# Altium schematic sheet Super Cap_PowerSupply.SchDoc
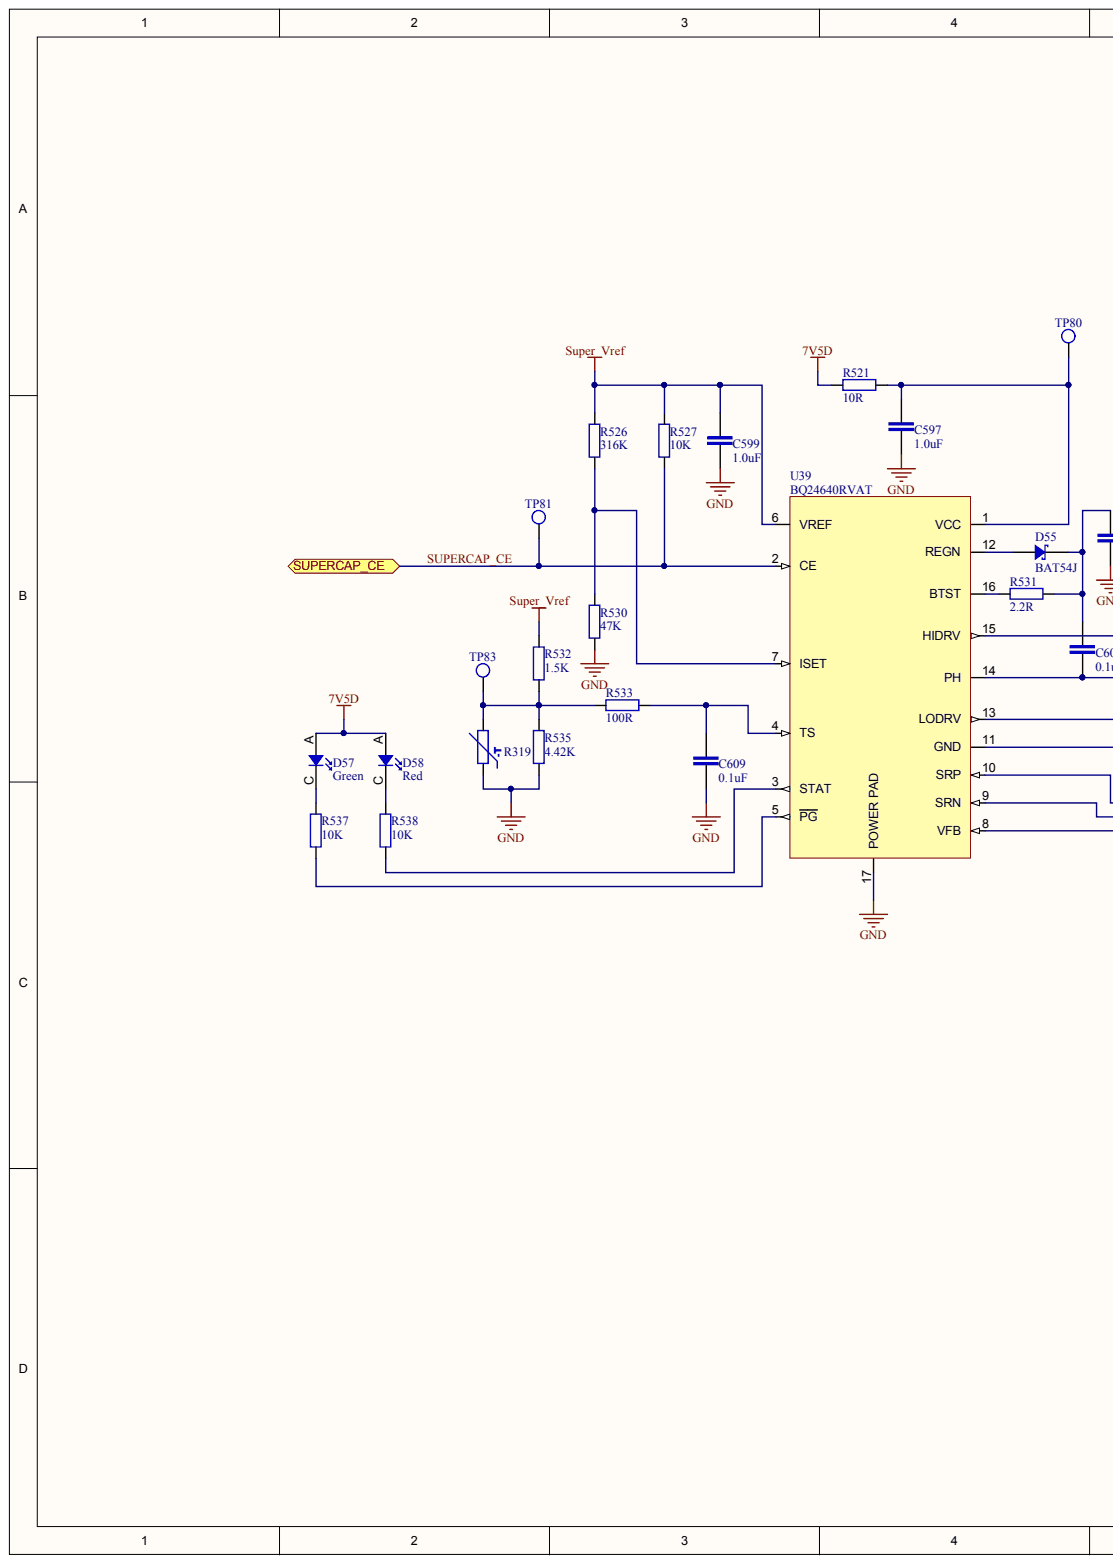
[diagram p0: Super Cap_PowerSupply.SchDoc, left]
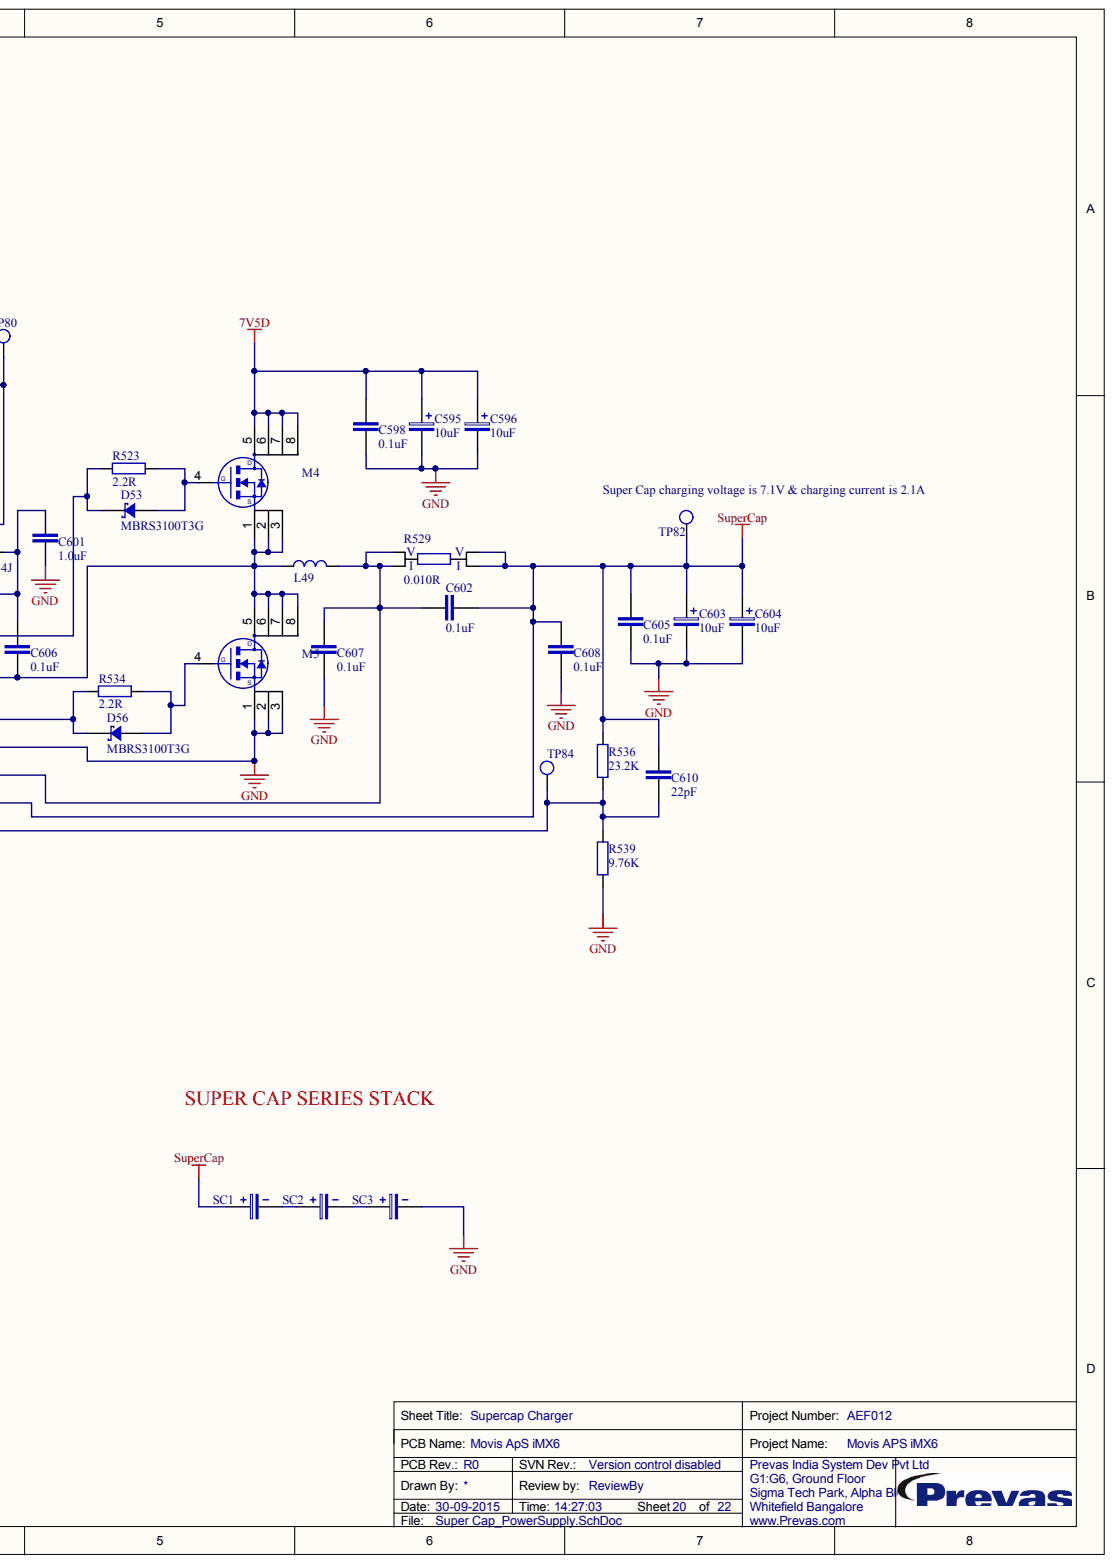
[diagram p1: Super Cap_PowerSupply.SchDoc, right]

## Parts
C595  10uF  [CAPMP7343X200N]
C596  10uF  [CAPMP7343X200N]
C597  1.0uF  [CAPC1608X90N]
C598  0.1uF  [CAPC1005X55N]
C599  1.0uF  [CAPC1608X90N]
C601  1.0uF  [CAPC1608X90N]
C602  0.1uF  [CAPC1005X55N]
C603  10uF  [CAPMP7343X200N]
C604  10uF  [CAPMP7343X200N]
C605  0.1uF  [CAPC1005X55N]
C606  0.1uF  [CAPC1005X55N]
C607  0.1uF  [CAPC1005X55N]
C608  0.1uF  [CAPC1005X55N]
C609  0.1uF  [CAPC1005X55N]
C610  22pF  [CAPC1005X55N]
D53  MBRS3100T3G  [DIOM7959X241AN]
D55  BAT54J  [SOD323F]
D56  MBRS3100T3G  [DIOM7959X241AN]
D57  Green  [LED_19-219_G7C-BK1L2VY_3T]
D58  Red  [LED_19-219_G7C-BK1L2VY_3T]
L49  6.8 uH  [INDM103103X40N]  (value not drawn)
M4  CSD19533Q5A  [SON127P600X110_HS-8N]  (value not drawn)
M5  CSD19533Q5A  [SON127P600X110_HS-8N]  (value not drawn)
R319  RES_NTC  [RESC1608X95N]  (value not drawn)
R521  10R  [RESC1608X55N]
R523  2.2R  [RESC2012X60N]
R526  316K  [RESC1608X55N]
R527  10K  [RESC1608X55N]
R529  0.010R  [RES_LVK12R010DER]
R530  47K  [RESC1608X55N]
R531  2.2R  [RESC2012X60N]
R532  1.5K  [RESC1608X55N]
R533  100R  [RESC1608X55N]
R534  2.2R  [RESC2012X60N]
R535  4.42K  [RESC1608X55N]
R536  23.2K  [RESC1608X55N]
R537  10K  [RESC1608X55N]
R538  10K  [RESC1608X55N]
R539  9.76K  [RESC1608X55N]
SC1  50F  [BCAP0050 P270 T01]  (value not drawn)
SC2  50F  [BCAP0050 P270 T01]  (value not drawn)
SC3  50F  [BCAP0050 P270 T01]  (value not drawn)
TP80  Testpoint  [TP_SMD]  (value not drawn)  (no BOM)
TP81  Testpoint  [TP_SMD]  (value not drawn)  (no BOM)
TP82  Testpoint  [TP_SMD]  (value not drawn)  (no BOM)
TP83  Testpoint  [TP_SMD]  (value not drawn)  (no BOM)
TP84  Testpoint  [TP_SMD]  (value not drawn)  (no BOM)
U39  BQ24640RVAT  [QFN50P350X350X100_HS-17N]

## Ports
SUPERCAP_CE

## Nets
7V5D: C595.1 C596.1 C598.2 D57.A D58.A M4.5 M4.6 M4.7 M4.8 R521.1
GND: C595.2 C596.2 C597.1 C598.1 C599.1 C601.1 C603.2 C604.2 C605.1 C607.2 C608.2 C609.1 M5.1 M5.2 M5.3 R319.1 R530.1 R535.1 R539.1 SC3.2 U39.11(GND) U39.17(POWER_PAD)
SUPER_VREF: C599.2 R526.2 R527.2 R532.2 U39.6(VREF)
SUPERCAP: C602.1 C603.1 C604.1 C605.2 C608.1 C610.2 R529.3(I) R529.4(V) R536.2 SC1.1 TP82.1 U39.9(SRN)
SUPERCAP_CE: R527.1 TP81.1 U39.2(CE) ; port SUPERCAP_CE
NetC597_2: C597.2 R521.2 TP80.1 U39.1(VCC)
NetC601_2: C601.2 C606.2 D55.C R531.2
NetC602_2: C602.2 C607.1 L49.2 R529.1(V) R529.2(I) U39.10(SRP)
NetC606_1: C606.1 L49.1 M4.1 M4.2 M4.3 M5.5 M5.6 M5.7 M5.8 U39.14(PH)
NetC609_2: C609.2 R533.2 U39.4(TS)
NetC610_1: C610.1 R536.1 R539.2 TP84.1 U39.8(VFB)
NetD53_A: D53.A M4.4(G) R523.2
NetD53_C: D53.C R523.1 U39.15(HIDRV)
NetD55_A: D55.A U39.12(REGN)
NetD56_A: D56.A M5.4 R534.2
NetD56_C: D56.C R534.1 U39.13(LODRV)
NetD57_C: D57.C R537.2
NetD58_C: D58.C R538.2
NetR319_2: R319.2 R532.1 R533.1 R535.2 TP83.1
NetR526_1: R526.1 R530.2 U39.7(ISET)
NetR531_1: R531.1 U39.16(BTST)
NetR537_1: R537.1 U39.5(PG)
NetR538_1: R538.1 U39.3(STAT)
NetSC1_2: SC1.2 SC2.1
NetSC2_2: SC2.2 SC3.1

## Library data of the parts
C595 C596 C603 C604 = IBAT-24: Solid Tantalum Standard; ref_part_type: /capacitor/polarized/polymer/; source_manufacturer_1: AVX; source_manufacturer_1_pn: TPSD106K035R0125; cae_altium_pcb_status: Prototype; Database Table Name: altium_default; db_rev: 1331; elec_temp_range: -55 to 125; elec_tolerance: 10%; elec_voltage_rating: 35V; phy_height: 7.3mm; phy_mounting: SMD; phy_packaging_type: D-case; ref_datasheet: IBAT-20; cae_altium_sch_status: Prototype; Component Kind: Standard; ComponentLink1Description: Datasheet; ComponentLink1URL: https://cop201.prevas.dk/viewvc/datasheet/IBAT-20.pdf?root=hw_components&view=co; db_created: 2008-11-07 13:55:01; db_last_updated: 20-05-2009 15:28:47; db_local_id: IBAT-24; db_status: Prototype; elec_value: 10uF; phy_material: Tantalum; Library Name: altium_mysql.dblib
C597 C599 C601 = INNISI-79: CAP CER 1UF 16V 10% X7R 0603; ref_part_type: /capacitor/non_polarized/ceramic/; source_manufacturer_1: Murata; source_manufacturer_1_pn: GRM188R71C105KE15D; cae_altium_pcb_status: Prototype; Database Table Name: altium_default; db_rev: 7576; elec_temp_range: -55 to +125; phy_height: 0.90mm; phy_mounting: SMD; phy_packaging_type: 0603 (1608 Metric); ref_datasheet: INNISI-33; ref_keyword: Ceramic Capacitor 0603; cae_altium_sch_status: Prototype; Component Kind: Standard; ComponentLink1Description: Datasheet; ComponentLink1URL: https://cop201.prevas.dk/viewvc/datasheet/INNISI-33.pdf?root=hw_components&view=co; db_created: 2015-08-03 05:39:06.0; db_last_updated: 03-08-2015 05:39:08; db_local_id: INNISI-79; db_status: Prototype; elec_tolerance: 10%; elec_value: 1.0uF; elec_voltage_rating: 16V; phy_material: X7R; Library Name: altium_mysql.dblib
C598 C602 C605-C609 = INNISI-73: CAP CER 0.1UF 50V 10% X5R 0402; ref_part_type: /capacitor/non_polarized/ceramic/; source_manufacturer_1: TDK Corporation; source_manufacturer_1_pn: C1005X5R1H104K050BB; cae_altium_pcb_status: Prototype; Database Table Name: altium_default; db_rev: 7569; elec_temp_range: -55 to +85 C; phy_height: 0.55mm; phy_mounting: SMD; phy_packaging_type: 0402; ref_datasheet: INNISI-71; cae_altium_sch_status: Prototype; Component Kind: Standard; ComponentLink1Description: Datasheet; ComponentLink1URL: https://cop201.prevas.dk/viewvc/datasheet/INNISI-71.pdf?root=hw_components&view=co; db_created: 2015-08-03 03:34:59.0; db_last_updated: 03-08-2015 03:35:01; db_local_id: INNISI-73; db_status: Prototype; elec_tolerance: ±10%; elec_value: 0.1uF; elec_voltage_rating: 50V; phy_material: X5R; ref_keyword: CAP CER 0.1UF 50V 10% X5R 0402; Library Name: altium_mysql.dblib
C610 = INNISI-83: CAP CER 22PF 50V 5% NP0 0402; ref_part_type: /capacitor/non_polarized/ceramic/; source_manufacturer_1: Murata; source_manufacturer_1_pn: GCM1555C1H220JA16D; cae_altium_pcb_status: Prototype; Database Table Name: altium_default; db_rev: 7582; elec_temp_range: -55 to +125C; phy_height: 0.55mm; phy_material: C0G; phy_mounting: SMD; phy_packaging_type: 0402; ref_datasheet: INNISI-76; ref_keyword: Ceramic capacitor; cae_altium_sch_status: Prototype; Component Kind: Standard; ComponentLink1Description: Datasheet; ComponentLink1URL: https://cop201.prevas.dk/viewvc/datasheet/INNISI-76.pdf?root=hw_components&view=co; db_created: 2015-08-03 06:17:55.0; db_last_updated: 03-08-2015 06:17:58; db_local_id: INNISI-83; db_status: Prototype; elec_tolerance: 5%; elec_value: 22pF; elec_voltage_rating: 50V; Library Name: altium_mysql.dblib
D53 D56 = MITO-15: Diode Power Rectifier Case 403; ref_part_type: /diode/schottky/; source_manufacturer_1: ON Semiconductor; cae_altium_pcb_status: Prototype; Database Table Name: altium_default; db_rev: 2168; elec_current_rating: 3A; elec_temp_range: -65 to +175°C; elec_voltage_rating: 100V; phy_height: 2.41mm; phy_material: Epoxy; phy_mounting: SMD; ref_datasheet: MITO-14; ref_keyword: Schottky Diode SMD; cae_altium_sch_status: Prototype; Component Kind: Standard; ComponentLink1Description: Datasheet; ComponentLink1URL: https://cop201.prevas.dk/viewvc/datasheet/MITO-14.pdf?root=hw_components&view=co; db_created: 2008-10-08 14:04:15; db_last_updated: 03-03-2010 14:01:53; db_local_id: MITO-15; db_status: Prototype; elec_value: MBRS3100T3G; phy_packaging_type: Case 403; source_manufacturer_1_pn: MBRS3100T3G; Library Name: altium_mysql.dblib
D55 = JEPE-154: Diode Single Schottky Barrier SOD323F; ref_part_type: /diode/schottky/; source_manufacturer_1: NXP Semiconductors; cae_altium_pcb_status: Prototype; Database Table Name: altium_default; db_rev: 2165; elec_current_rating: 200mA; elec_temp_range: -65 to 150; elec_voltage_rating: 30V reverse; phy_height: 0.80mm; phy_mounting: SMD; phy_packaging_type: SOD323F/SC-90; ref_datasheet: JEPE-108; ref_keyword: Schottky Diode SMD; cae_altium_sch_status: Prototype; Component Kind: Standard; ComponentLink1Description: Datasheet; ComponentLink1URL: https://cop201.prevas.dk/viewvc/datasheet/JEPE-108.pdf?root=hw_components&view=co; db_created: 2008-10-01 11:06:36; db_last_updated: 01-03-2010 13:53:59; db_local_id: JEPE-154; db_status: Prototype; elec_value: BAT54J; source_manufacturer_1_pn: BAT54J; Library Name: altium_mysql.dblib
D57 = EXTJOAL-8: LED Low Profile 0.2mm; ref_part_type: /optical/emmision/diode/; source_manufacturer_1: Everlight; source_manufacturer_1_pn: 19-219/G7C-BK1L2VY/3T; cae_altium_pcb_status: Prototype; Database Table Name: altium_default; db_rev: 6270; elec_current_rating: 25mA; elec_frequency_rating: 575nm; elec_power_rating: 60mW; elec_temp_range: -40 to 85C; phy_material: AlGaInP; phy_mounting: SMD; phy_packaging_type: 0603; ref_datasheet: JOON-130; cae_altium_sch_status: Prototype; Component Kind: Standard; ComponentLink1Description: Datasheet; ComponentLink1URL: https://cop201.prevas.dk/viewvc/datasheet/JOON-130.pdf?root=hw_components&view=co; db_created: 2014-01-22 18:15:53.0; db_last_updated: 22-01-2014 18:18:05; db_local_id: EXTJOAL-8; db_status: Prototype; elec_value: Green; phy_height: 0.2mm; ref_keyword: LED; Library Name: altium_mysql.dblib
D58 = EXTJOAL-16: LED Low Profile 0.2mm; ref_part_type: /optical/emmision/diode/; source_manufacturer_1: Everlight; source_manufacturer_1_pn: 19-219/R6C-AM1N2VY/3T; cae_altium_pcb_status: Prototype; Database Table Name: altium_default; db_rev: 6356; elec_current_rating: 25mA; elec_frequency_rating: 626nm; elec_power_rating: 60mW; elec_temp_range: -40 to 85C; phy_material: AlGaInP; phy_mounting: SMD; phy_packaging_type: 0603; ref_datasheet: EXTJOAL-7; cae_altium_sch_status: Prototype; Component Kind: Standard; ComponentLink1Description: Datasheet; ComponentLink1URL: https://cop201.prevas.dk/viewvc/datasheet/EXTJOAL-7.pdf?root=hw_components&view=co; db_created: 2014-02-25 11:33:38.0; db_last_updated: 25-02-2014 11:39:21; db_local_id: EXTJOAL-16; db_status: Prototype; elec_value: Red; phy_height: 0.2mm; ref_keyword: LED; Library Name: altium_mysql.dblib
L49 = INNISI-59: Fixed Inductors 6.8uH 20%; ref_part_type: /inductor/; source_manufacturer_1: Vishay; source_manufacturer_1_pn: IHLP4040DZER6R8M11; cae_altium_pcb_status: Prototype; Database Table Name: altium_default; db_rev: 7439; elec_current_rating: 9 A; elec_temp_range: - 55 C to + 125 C; phy_height: 4.0mm; phy_mounting: SMD; phy_packaging_type: 4040; ref_datasheet: INNISI-57; cae_altium_sch_status: Prototype; Component Kind: Standard; ComponentLink1Description: Datasheet; ComponentLink1URL: https://cop201.prevas.dk/viewvc/datasheet/INNISI-57.pdf?root=hw_components&view=co; db_created: 2015-06-16 04:47:30.0; db_last_updated: 16-06-2015 04:47:32; db_local_id: INNISI-59; db_status: Prototype; elec_tolerance: 20 %; elec_value: 6.8 uH; ref_keyword: Fixed Inductors; Library Name: altium_mysql.dblib
M4 M5 = INNISI-52: MOSFET, N-channel; ref_part_type: /transistor/mosfet/n_channel/; source_manufacturer_1: Texas Instruments; cae_altium_pcb_status: Prototype; Database Table Name: altium_default; db_rev: 7409; elec_current_rating: 75A; elec_power_rating: 3.2W; elec_temp_range: -55°C to +150°C; elec_voltage_rating: 100V; phy_mounting: SMD; phy_packaging_type: 8-TDFN Exposed Pad; ref_datasheet: INNISI-50; cae_altium_sch_status: Prototype; Component Kind: Standard; ComponentLink1Description: Datasheet; ComponentLink1URL: https://cop201.prevas.dk/viewvc/datasheet/INNISI-50.pdf?root=hw_components&view=co; db_created: 2015-06-09 04:16:36.0; db_last_updated: 09-06-2015 04:16:38; db_local_id: INNISI-52; db_status: Prototype; elec_value: CSD19533Q5A; ref_keyword: MOSFET, N-channel; source_manufacturer_1_pn: CSD19533Q5A; Library Name: altium_mysql.dblib
R319 = INNISI-58: NTC thermistor; ref_part_type: /resistor/ntc/; source_manufacturer_1: EPCOS / TDK; source_manufacturer_1_pn: B57321V2103H60; cae_altium_pcb_status: Prototype; Database Table Name: altium_default; db_rev: 7438; elec_power_rating: 180 mW; elec_temp_range: - 55 C to + 125 C; elec_tolerance: 3 %; elec_value: 10.0K; phy_height: 0.9mm; phy_mounting: SMD; phy_packaging_type: 0603; ref_datasheet: INNISI-56; cae_altium_sch_status: Prototype; Component Kind: Standard; ComponentLink1Description: Datasheet; ComponentLink1URL: https://cop201.prevas.dk/viewvc/datasheet/INNISI-56.pdf?root=hw_components&view=co; db_created: 2015-06-16 04:27:12.0; db_last_updated: 16-06-2015 04:27:14; db_local_id: INNISI-58; db_status: Prototype; ref_keyword: NTC; Library Name: altium_mysql.dblib
R521 = INVIHA-55: RES SMD 10 OHM 1% 1/4W 0603; ref_part_type: /resistor/fixed/; source_manufacturer_1: Vishay Dale; source_manufacturer_1_pn: CRCW060310R0FKEAHP; cae_altium_pcb_status: Prototype; Database Table Name: altium_default; db_rev: 7688; elec_power_rating: 0.25W; elec_temp_range: -55 to +155° C; phy_height: 0.55mm; phy_packaging_type: 0603; ref_datasheet: INVIHA-13; ref_keyword: Chip Resistor; cae_altium_sch_status: Prototype; Component Kind: Standard; ComponentLink1Description: Datasheet; ComponentLink1URL: https://cop201.prevas.dk/viewvc/datasheet/INVIHA-13.pdf?root=hw_components&view=co; db_created: 2015-08-06 06:12:47.0; db_last_updated: 06-08-2015 06:12:49; db_local_id: INVIHA-55; db_status: Prototype; elec_tolerance: 1%; elec_value: 10R; phy_mounting: SMD; Library Name: altium_mysql.dblib
R523 R531 R534 = GEPW-310: Resistor 0805 General Purpose; ref_part_type: /resistor/fixed/; source_manufacturer_1: Phycomp; source_manufacturer_1_pn: 2322734*2208L; cae_altium_pcb_status: Prototype; Database Table Name: altium_default; db_rev: 7133; elec_current_rating: 2A; elec_power_rating: 0.125W; elec_temp_range: -55 to +155; elec_tolerance: 1%; elec_voltage_rating: 150V; phy_height: 0.60mm; phy_material: Thick film; phy_mounting: SMD; phy_packaging_type: 0805; ref_datasheet: CHKJ-6; ref_keyword: Thick film chip resistor; cae_altium_sch_status: Prototype; Component Kind: Standard; ComponentLink1Description: Datasheet; ComponentLink1URL: https://cop201.prevas.dk/viewvc/datasheet/CHKJ-6.pdf?root=hw_components&view=co; db_created: 2015-03-16 14:54:02.0; db_last_updated: 16-03-2015 14:54:03; db_local_id: GEPW-310; db_status: Prototype; elec_value: 2.2R; Library Name: altium_mysql.dblib
R526 = INVIHA-40: RES SMD 316K OHM 1% 1/10W 0603; ref_part_type: /resistor/fixed/; source_manufacturer_1: Yageo; source_manufacturer_1_pn: RC0603FR-07316KL; cae_altium_pcb_status: Prototype; Database Table Name: altium_default; db_rev: 7634; elec_power_rating: 0.1W; elec_temp_range: -55 to +155° C; phy_height: 0.55mm; phy_packaging_type: 0603; ref_datasheet: INVIHA-2; ref_keyword: Chip Resistor; cae_altium_sch_status: Prototype; Component Kind: Standard; ComponentLink1Description: Datasheet; ComponentLink1URL: https://cop201.prevas.dk/viewvc/datasheet/INVIHA-2.pdf?root=hw_components&view=co; db_created: 2015-08-03 09:25:51.0; db_last_updated: 03-08-2015 9:25:53 AM; db_local_id: INVIHA-40; db_status: Prototype; elec_tolerance: 1%; elec_value: 316K; phy_mounting: SMD; Library Name: altium_mysql.dblib
R527 R537 R538 = INVIHA-3: RES SMD 10K OHM 1% 1/10W 0603; ref_part_type: /resistor/fixed/; source_manufacturer_1: Yageo; source_manufacturer_1_pn: RC0603FR-0710KL; cae_altium_pcb_status: Prototype; Database Table Name: altium_default; db_rev: 7575; elec_power_rating: 0.1W; elec_temp_range: -55 to +155° C; phy_height: 0.55mm; phy_packaging_type: 0603; ref_datasheet: INVIHA-2; ref_keyword: Chip Resistor; cae_altium_sch_status: Prototype; Component Kind: Standard; ComponentLink1Description: Datasheet; ComponentLink1URL: https://cop201.prevas.dk/viewvc/datasheet/INVIHA-2.pdf?root=hw_components&view=co; db_created: 2015-08-03 05:39:03.0; db_last_updated: 03-08-2015 5:39:05 AM; db_local_id: INVIHA-3; db_status: Prototype; elec_tolerance: 1%; elec_value: 10K; phy_mounting: SMD; Library Name: altium_mysql.dblib
R529 = GEPW-192: Resistor 1206 Current Sense 50ppm; ref_part_type: /resistor/fixed/; source_manufacturer_1: OHMITE; source_manufacturer_1_pn: LVK12R010DER; cae_altium_pcb_status: Prototype; Database Table Name: altium_default; db_rev: 3469; elec_power_rating: 0.5W; elec_temp_range: -40 to +125; elec_tolerance: 0,1%; phy_height: 0.65; phy_mounting: SMD; phy_packaging_type: 1206; ref_datasheet: GEPW-169; ref_keyword: Four Terminal High Precision Current Sense; cae_altium_sch_status: Prototype; Component Kind: Standard; ComponentLink1Description: Datasheet; ComponentLink1URL: https://cop201.prevas.dk/viewvc/datasheet/GEPW-169.pdf?root=hw_components&view=co; db_created: 2011-05-16 17:19:15.0; db_last_updated: 17-05-2011 09:40:46; db_local_id: GEPW-192; db_status: Prototype; elec_value: 0.010R; Library Name: altium_mysql.dblib
R530 = INVIHA-21: RES SMD 47K OHM 1% 1/10W 0603; ref_part_type: /resistor/fixed/; source_manufacturer_1: Yageo; source_manufacturer_1_pn: RC0603FR-0747KL; cae_altium_pcb_status: Prototype; Database Table Name: altium_default; db_rev: 7604; elec_power_rating: 0.1W; elec_temp_range: -55 to +155° C; phy_height: 0.55mm; phy_packaging_type: 0603; ref_keyword: Chip Resistor; cae_altium_sch_status: Prototype; Component Kind: Standard; ComponentLink1Description: Datasheet; ComponentLink1URL: https://cop201.prevas.dk/viewvc/datasheet/INVIHA-2.pdf?root=hw_components&view=co; db_created: 2015-08-03 07:24:13.0; db_last_updated: 03-08-2015 7:24:15 AM; db_local_id: INVIHA-21; db_status: Prototype; elec_tolerance: 1%; elec_value: 47K; phy_mounting: SMD; ref_datasheet: INVIHA-2; Library Name: altium_mysql.dblib
R532 = INVIHA-41: RES SMD 1.5K OHM 1% 1/10W 0603; ref_part_type: /resistor/fixed/; source_manufacturer_1: Yageo; source_manufacturer_1_pn: RC0603FR-071K5L; cae_altium_pcb_status: Prototype; Database Table Name: altium_default; db_rev: 7635; elec_power_rating: 0.1W; elec_temp_range: -55 to +155° C; phy_height: 0.55mm; phy_packaging_type: 0603; ref_datasheet: INVIHA-2; ref_keyword: Chip Resistor; cae_altium_sch_status: Prototype; Component Kind: Standard; ComponentLink1Description: Datasheet; ComponentLink1URL: https://cop201.prevas.dk/viewvc/datasheet/INVIHA-2.pdf?root=hw_components&view=co; db_created: 2015-08-03 09:29:02.0; db_last_updated: 03-08-2015 9:29:03 AM; db_local_id: INVIHA-41; db_status: Prototype; elec_tolerance: 1%; elec_value: 1.5K; phy_mounting: SMD; Library Name: altium_mysql.dblib
R533 = INVIHA-6: RES SMD 100 OHM 1% 1/10W 0603; ref_part_type: /resistor/fixed/; source_manufacturer_1: Yageo; source_manufacturer_1_pn: RC0603FR-07100RL; cae_altium_pcb_status: Prototype; Database Table Name: altium_default; db_rev: 7583; elec_power_rating: 0.1W; elec_temp_range: -55 to +155° C; phy_height: 0.55mm; phy_packaging_type: 0603; ref_datasheet: INVIHA-2; ref_keyword: Chip Resistor; cae_altium_sch_status: Prototype; Component Kind: Standard; ComponentLink1Description: Datasheet; ComponentLink1URL: https://cop201.prevas.dk/viewvc/datasheet/INVIHA-2.pdf?root=hw_components&view=co; db_created: 2015-08-03 06:19:06.0; db_last_updated: 03-08-2015 6:19:07 AM; db_local_id: INVIHA-6; db_status: Prototype; elec_tolerance: 1%; elec_value: 100R; phy_mounting: SMD; Library Name: altium_mysql.dblib
R535 = INVIHA-42: RES SMD 4.42K OHM 1% 1/10W 0603; ref_part_type: /resistor/fixed/; source_manufacturer_1: Yageo; source_manufacturer_1_pn: RC0603FR-074K42L; cae_altium_pcb_status: Prototype; Database Table Name: altium_default; db_rev: 7636; elec_power_rating: 0.1W; elec_temp_range: -55 to +155° C; phy_height: 0.55mm; phy_packaging_type: 0603; ref_datasheet: INVIHA-2; ref_keyword: Chip Resistor; cae_altium_sch_status: Prototype; Component Kind: Standard; ComponentLink1Description: Datasheet; ComponentLink1URL: https://cop201.prevas.dk/viewvc/datasheet/INVIHA-2.pdf?root=hw_components&view=co; db_created: 2015-08-03 09:31:27.0; db_last_updated: 03-08-2015 9:31:29 AM; db_local_id: INVIHA-42; db_status: Prototype; elec_tolerance: 1%; elec_value: 4.42K; phy_mounting: SMD; Library Name: altium_mysql.dblib
R536 = INVIHA-43: RES SMD 23.2K OHM 1% 1/10W 0603; ref_part_type: /resistor/fixed/; source_manufacturer_1: Yageo; source_manufacturer_1_pn: RC0603FR-0723K2L; cae_altium_pcb_status: Prototype; Database Table Name: altium_default; db_rev: 7637; elec_power_rating: 0.1W; elec_temp_range: -55 to +155° C; phy_height: 0.55mm; phy_packaging_type: 0603; ref_datasheet: INVIHA-2; ref_keyword: Chip Resistor; cae_altium_sch_status: Prototype; Component Kind: Standard; ComponentLink1Description: Datasheet; ComponentLink1URL: https://cop201.prevas.dk/viewvc/datasheet/INVIHA-2.pdf?root=hw_components&view=co; db_created: 2015-08-03 09:34:37.0; db_last_updated: 03-08-2015 9:34:39 AM; db_local_id: INVIHA-43; db_status: Prototype; elec_tolerance: 1%; elec_value: 23.2K; phy_mounting: SMD; Library Name: altium_mysql.dblib
R539 = INVIHA-44: RES SMD 9.76K OHM 1% 1/10W 0603; ref_part_type: /resistor/fixed/; source_manufacturer_1: Yageo; source_manufacturer_1_pn: RC0603FR-079K76L; cae_altium_pcb_status: Prototype; Database Table Name: altium_default; db_rev: 7639; elec_power_rating: 0.1W; elec_temp_range: -55 to +155° C; phy_height: 0.55mm; phy_packaging_type: 0603; ref_datasheet: INVIHA-2; ref_keyword: Chip Resistor; cae_altium_sch_status: Prototype; Component Kind: Standard; ComponentLink1Description: Datasheet; ComponentLink1URL: https://cop201.prevas.dk/viewvc/datasheet/INVIHA-2.pdf?root=hw_components&view=co; db_created: 2015-08-03 09:38:08.0; db_last_updated: 03-08-2015 9:38:09 AM; db_local_id: INVIHA-44; db_status: Prototype; elec_tolerance: 1%; elec_value: 9.76K; phy_mounting: SMD; Library Name: altium_mysql.dblib
SC1-SC3 = INNISI-68: Supercapacitors / Ultracapacitors RADIAL 2.7V 50F; ref_part_type: /capacitor/polarized/; source_manufacturer_1_pn: Maxwell Technologies; cae_altium_pcb_status: Prototype; Database Table Name: altium_default; db_rev: 7504; elec_temp_range: - 40 C to + 65 C; elec_tolerance: 20%; elec_voltage_rating: 2.5 V; phy_mounting: Leaded; ref_datasheet: INNISI-67; cae_altium_sch_status: Prototype; Component Kind: Standard; ComponentLink1Description: Datasheet; ComponentLink1URL: https://cop201.prevas.dk/viewvc/datasheet/INNISI-67.pdf?root=hw_components&view=co; db_created: 2015-07-07 08:38:03.0; db_last_updated: 07-07-2015 8:38:05 AM; db_local_id: INNISI-68; db_status: Prototype; elec_value: 50F; ref_keyword: Supercapacitor; source_manufacturer_1: BCAP0050 P270 T01; Library Name: altium_mysql.dblib
TP80-TP84 = JEPE-114: Test Point SMD; ref_part_type: /pcb_item/; cae_altium_pcb_status: Prototype; Database Table Name: altium_default; db_rev: 3281; cae_altium_sch_status: Prototype; ComponentLink1Description: Datasheet; db_created: 2008-08-18 11:39:42; db_last_updated: 23-03-2011 14:14:06; db_local_id: JEPE-114; db_status: Prototype; elec_value: Test Point; phy_mounting: SMD; ref_keyword: Test  Point; Library Name: altium_mysql.dblib
U39 = INKRMA-40: High-Efficiency Synchronous Switch-Mode Super Capacitor Charger; ref_part_type: /integrated/analog/power/supply/switching/; source_manufacturer_1: TEXAS INSTRUMENTS; cae_altium_pcb_status: Prototype; Database Table Name: altium_default; db_rev: 7429; elec_temp_range: -40deg to +155deg; elec_voltage_rating: 5 V to 28 V; phy_height: 1.0mm; phy_mounting: SMD; phy_packaging_type: QFN-16; ref_datasheet: INKRMA-44; cae_altium_sch_status: Prototype; Component Kind: Standard; ComponentLink1Description: Datasheet; ComponentLink1URL: https://cop201.prevas.dk/viewvc/datasheet/INKRMA-44.pdf?root=hw_components&view=co; db_created: 2015-06-10 06:44:40.0; db_last_updated: 10-06-2015 06:44:42; db_local_id: INKRMA-40; db_status: Prototype; elec_value: BQ24640RVAT; ref_keyword: Charger; source_manufacturer_1_pn: BQ24640RVAT; Library Name: altium_mysql.dblib
